# Altium schematic sheet TempSensor.SchDoc
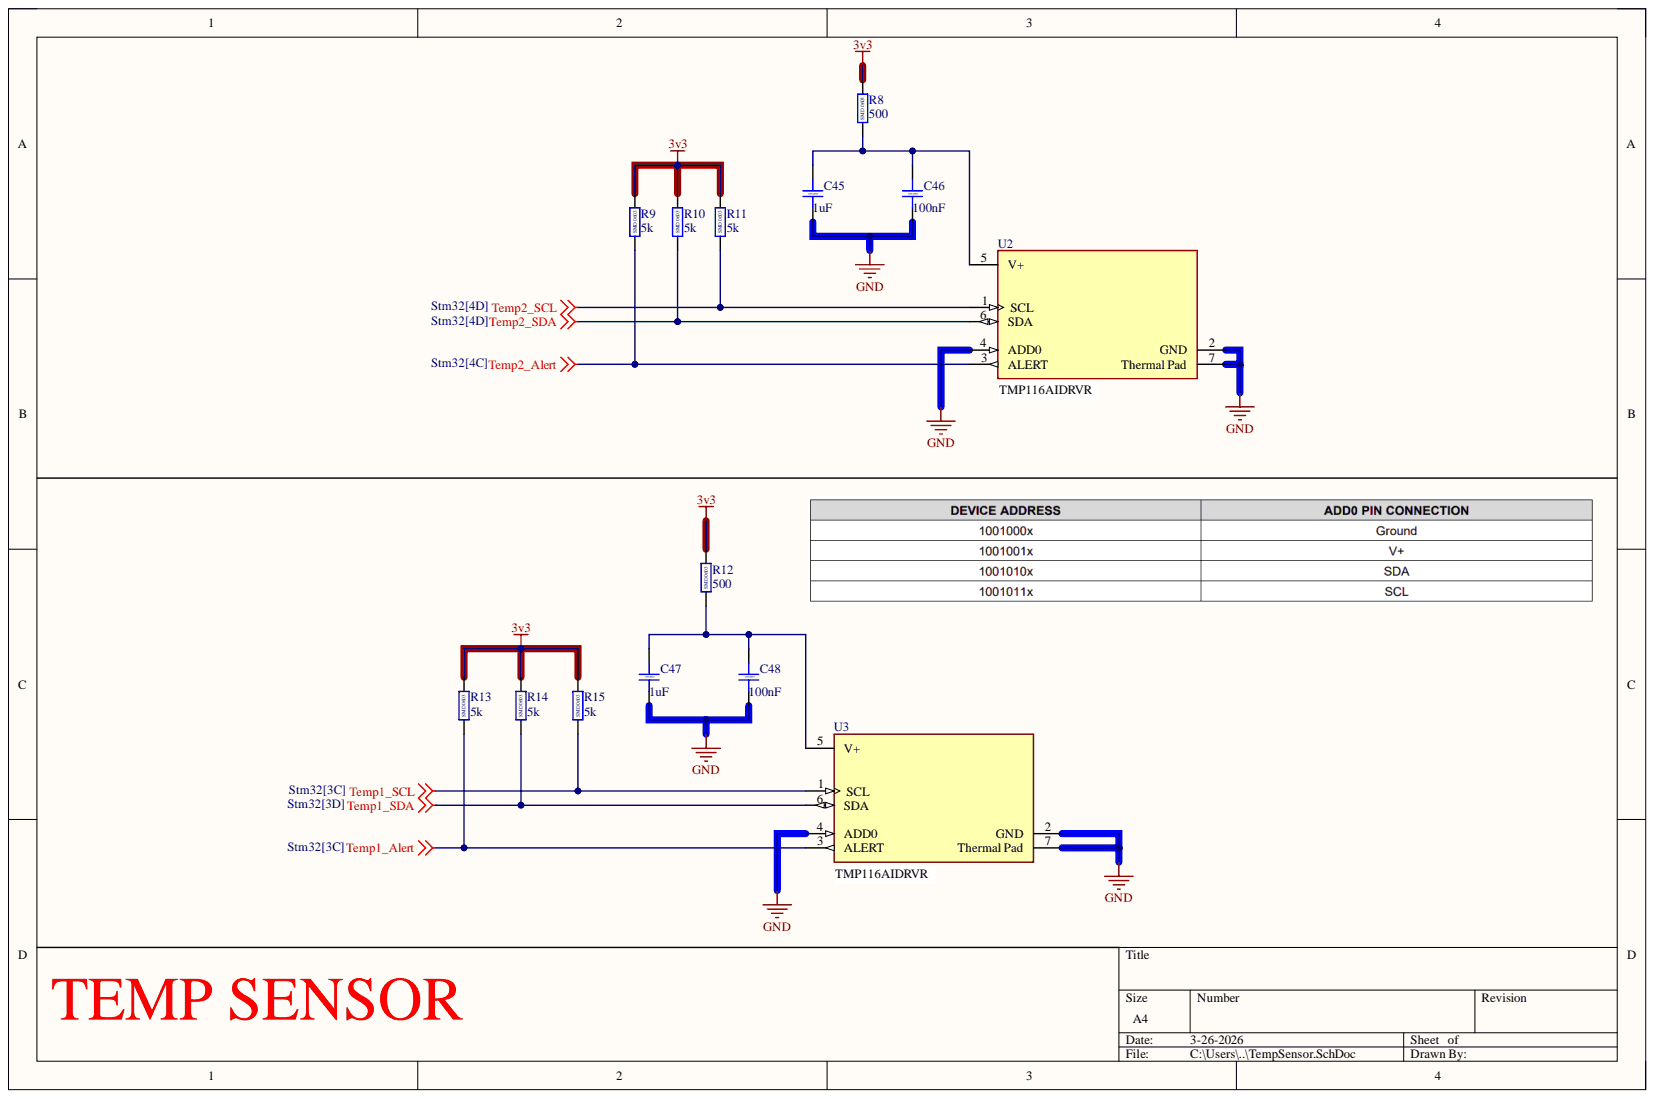
[diagram p0: TempSensor.SchDoc]

## Parts
C45  1uF  [CAP_0603]
C46  100nF  [CAP_0603]
C47  1uF  [CAP_0603]
C48  100nF  [CAP_0603]
R8  500  [RES_0603]
R9  5k  [RES_0603]
R10  5k  [RES_0603]
R11  5k  [RES_0603]
R12  500  [RES_0603]
R13  5k  [RES_0603]
R14  5k  [RES_0603]
R15  5k  [RES_0603]
U2  (no value)  [FP-DRV0006A-IPC_C]
U3  (no value)  [FP-DRV0006A-IPC_C]

## Nets
3v3: R8.2 R9.2 R10.2 R11.2 R12.2 R13.2 R14.2 R15.2
GND: C45.1 C46.1 C47.1 C48.1 U2.2(GND) U2.4(ADD0) U2.7(Thermal_Pad) U3.2(GND) U3.4(ADD0) U3.7(Thermal_Pad)
Temp1_Alert: R13.1 U3.3(ALERT)
Temp1_SCL: R15.1 U3.1(SCL)
Temp1_SDA: R14.1 U3.6(SDA)
Temp2_Alert: R9.1 U2.3(ALERT)
Temp2_SCL: R11.1 U2.1(SCL)
Temp2_SDA: R10.1 U2.6(SDA)
NetC45_2: C45.2 C46.2 R8.1 U2.5(V+)
NetC47_2: C47.2 C48.2 R12.1 U3.5(V+)

## Library data of the parts
C45-C48 = Capacitor-SMD-0603: Capacitor SMD 0603 RATED; Component Kind: Standard; Library Name: STeRG-Passives-Standard.SchLib
R8-R15 = Resistor-SMD-0603: Resistor SMD 0603 RATED; Component Kind: Standard; Library Name: STeRG-Passives-Standard.SchLib
U2 U3 = CMP-04948-000045-1: SENSOR DIGITAL -55C-125C 6WSON; Interface: I2C; Resolution: 16b; Component Kind: Standard; Library Name: Altium Content Vault; Max Operating Temperature: 150°C; Min Operating Temperature: -55°C; Mount: Surface Mount; Output Type: I2C; Packaging: Tape and Reel; RoHS Compliant: Yes
